# Altium schematic sheet Sersor.SchDoc(Sersor)
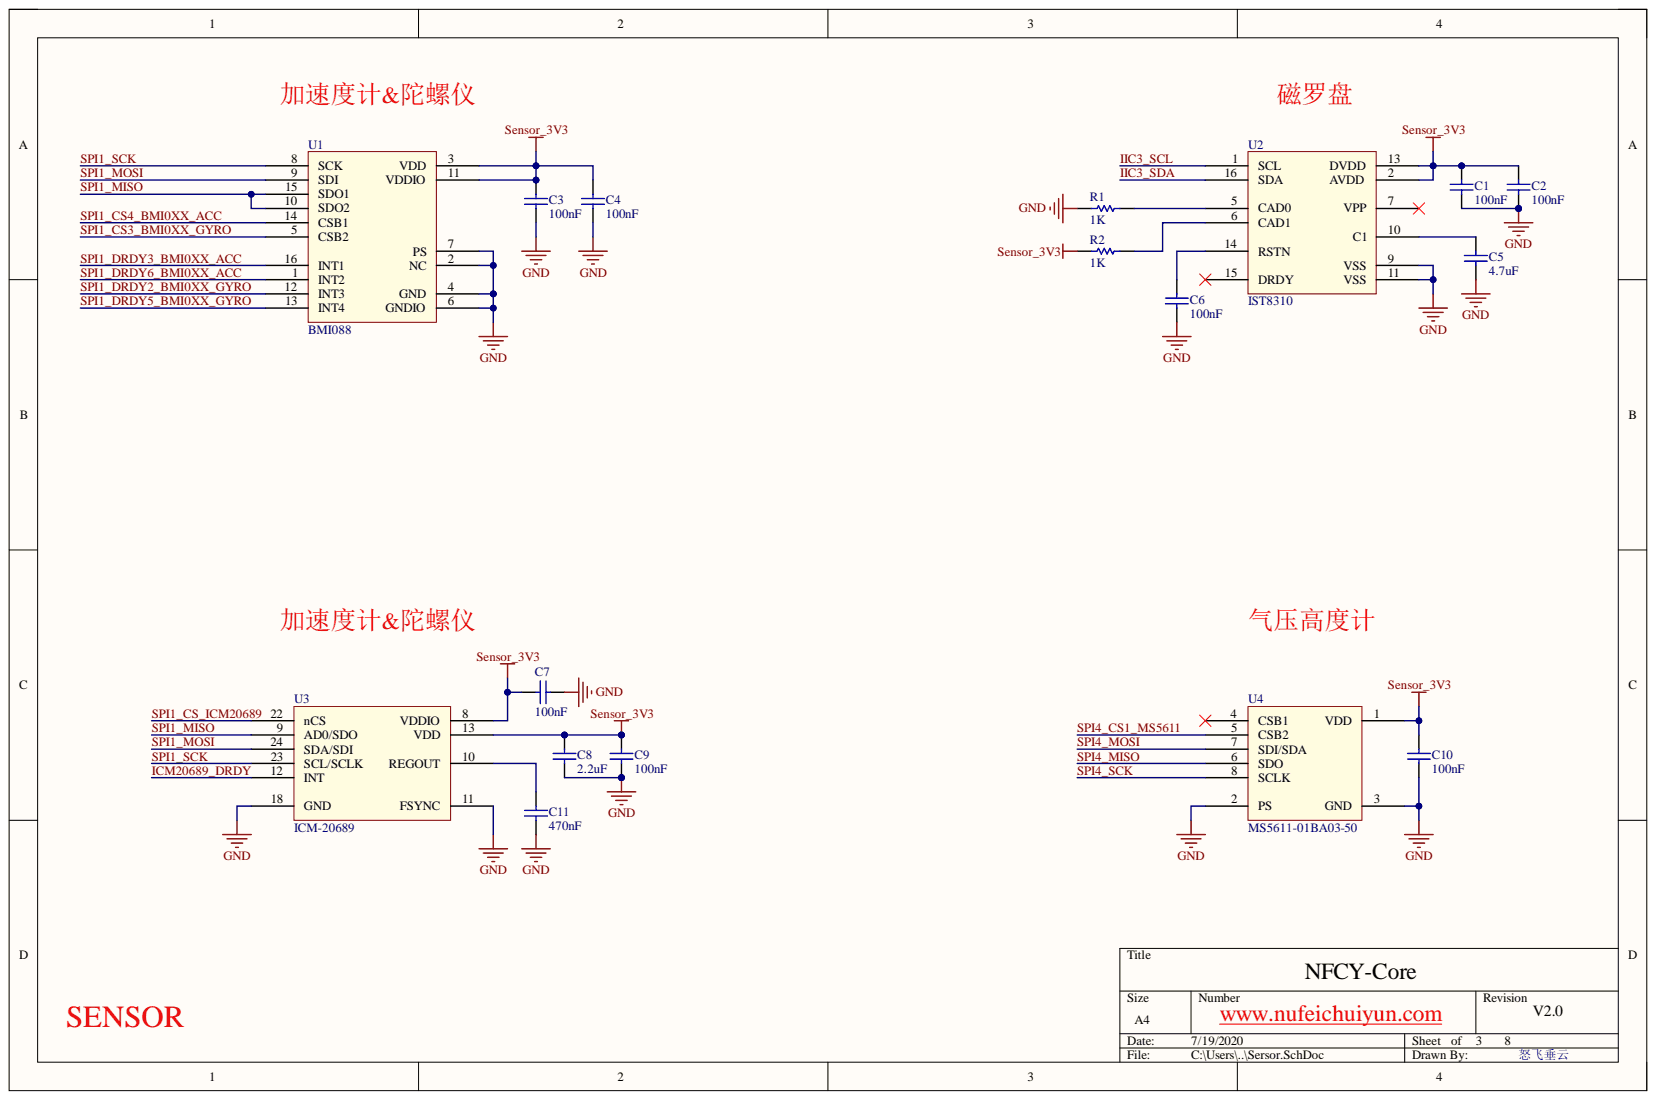
[diagram p0: Sersor.SchDoc]

## Parts
C1  value 100nF  [CAP0402]  (hidden comment: CL05B104KO5NNNC)
C2  value 100nF  [CAP0402]  (hidden comment: CL05B104KO5NNNC)
C3  value 100nF  [CAP0402]  (hidden comment: CL05B104KO5NNNC)
C4  value 100nF  [CAP0402]  (hidden comment: CL05B104KO5NNNC)
C5  value 4.7uF  [CAP0402]  (hidden comment: CL05A475KP5NRNC)
C6  value 100nF  [CAP0402]  (hidden comment: CL05B104KO5NNNC)
C7  value 100nF  [CAP0402]  (hidden comment: CL05B104KO5NNNC)
C8  value 2.2uF  [CAP0402]  (hidden comment: CL05A225KP5NSNC)
C9  value 100nF  [CAP0402]  (hidden comment: CL05B104KO5NNNC)
C10  value 100nF  [CAP0402]  (hidden comment: CL05B104KO5NNNC)
C11  value 470nF  [CAP0402]  (hidden comment: CL05A474KP5NNNC)
R1  value 1K  [RES0402]  (hidden comment: RC0402FR-071KL)
R2  value 1K  [RES0402]  (hidden comment: RC0402FR-071KL)
U1  BMI088  [BMI0XX_LGA-16]
U2  IST8310  [LGA-16]
U3  ICM-20689  [ICM-QFN-24]
U4  MS5611-01BA03-50  [QFN-8]

## Nets
GND: C1.1 C2.1 C3.1 C4.1 C5.2 C6.1 C7.1 C8.2 C9.1 C10.1 C11.1 R1.2 U1.2(NC) U1.4(GND) U1.6(GNDIO) U1.7(PS) U2.9(VSS) U2.11(VSS) U3.11(FSYNC) U3.18(GND) U4.2(PS) U4.3(GND)
Sensor_3V3: C1.2 C2.2 C3.2 C4.2 C7.2 C8.1 C9.2 C10.2 R2.2 U1.3(VDD) U1.11(VDDIO) U2.2(AVDD) U2.13(DVDD) U3.8(VDDIO) U3.13(VDD) U4.1(VDD)
ICM20689_DRDY: U3.12(INT)
IIC3_SCL: U2.1(SCL)
IIC3_SDA: U2.16(SDA)
SPI1_CS3_BMI0XX_GYRO: U1.5(CSB2)
SPI1_CS4_BMI0XX_ACC: U1.14(CSB1)
SPI1_CS_ICM20689: U3.22(nCS)
SPI1_DRDY2_BMI0XX_GYRO: U1.12(INT3)
SPI1_DRDY3_BMI0XX_ACC: U1.16(INT1)
SPI1_DRDY5_BMI0XX_GYRO: U1.13(INT4)
SPI1_DRDY6_BMI0XX_ACC: U1.1(INT2)
SPI1_MISO: U1.10(SDO2) U1.15(SDO1) U3.9(AD0/SDO)
SPI1_MOSI: U1.9(SDI) U3.24(SDA/SDI)
SPI1_SCK: U1.8(SCK) U3.23(SCL/SCLK)
SPI4_CS1_MS5611: U4.5(CSB2)
SPI4_MISO: U4.6(SDO)
SPI4_MOSI: U4.7(SDI/SDA)
SPI4_SCK: U4.8(SCLK)
NetC5_1: C5.1 U2.10(C1)
NetC6_2: C6.2 U2.14(RSTN)
NetC11_2: C11.2 U3.10(REGOUT)
NetR1_1: R1.1 U2.5(CAD0)
NetR2_1: R2.1 U2.6(CAD1)

Not connected: U2 7(VPP) 15(DRDY); U4 4(CSB1)

## Library data of the parts
C1-C11 = Cap: 贴片电容; manufacturer: SAMSUNG(三星); Component Kind: Standard; LatestRevisionDate: 17-Jul-2002; LatestRevisionNote: Re-released for DXP Platform.; Library Name: Miscellaneous Devices.IntLib; PackageDescription: Radial Cap, Thru-Hole; 2 Leads; 0.3 in Pin Spacing; PackageReference: RAD-0.3; Published: 8-Jun-2000; Signal Integrity: Cap; Simulation: CAP; Publisher: Altium Limited
R1 R2 = Res1: 贴片电阻; manufacturer: YAGEO(国巨); Component Kind: Standard; LatestRevisionDate: 17-Jul-2002; LatestRevisionNote: Re-released for DXP Platform.; Library Name: Miscellaneous Devices.IntLib; PackageDescription: Axial Device, Thru-Hole; 2 Leads; 0.3 in Pin Spacing; PackageReference: AXIAL-0.3; Published: 8-Jun-2000; Signal Integrity: Res; Simulation: RESISTOR; Publisher: Altium Limited
U1 = BMI088: 三轴加速度计，三轴磁罗盘; manufacturer: Bosch(博世); Component Kind: Standard; Library Name: Chip.SchLib
U2 = IST8310: 三轴磁罗盘芯片; manufacturer: iSentek; Component Kind: Standard; Library Name: Chip.SchLib
U3 = ICM-20689: 三轴加速度计，三轴陀螺仪芯片; manufacturer: TDK; Component Kind: Standard; Library Name: Chip.SchLib
U4 = MS5611-01BA03-50: 气压高度计; manufacturer: MEASUREMENT SPECIALTIES; Component Kind: Standard; Library Name: Chip.SchLib
